# Altium schematic sheet MCU_SUPPORT_XS.SchDoc
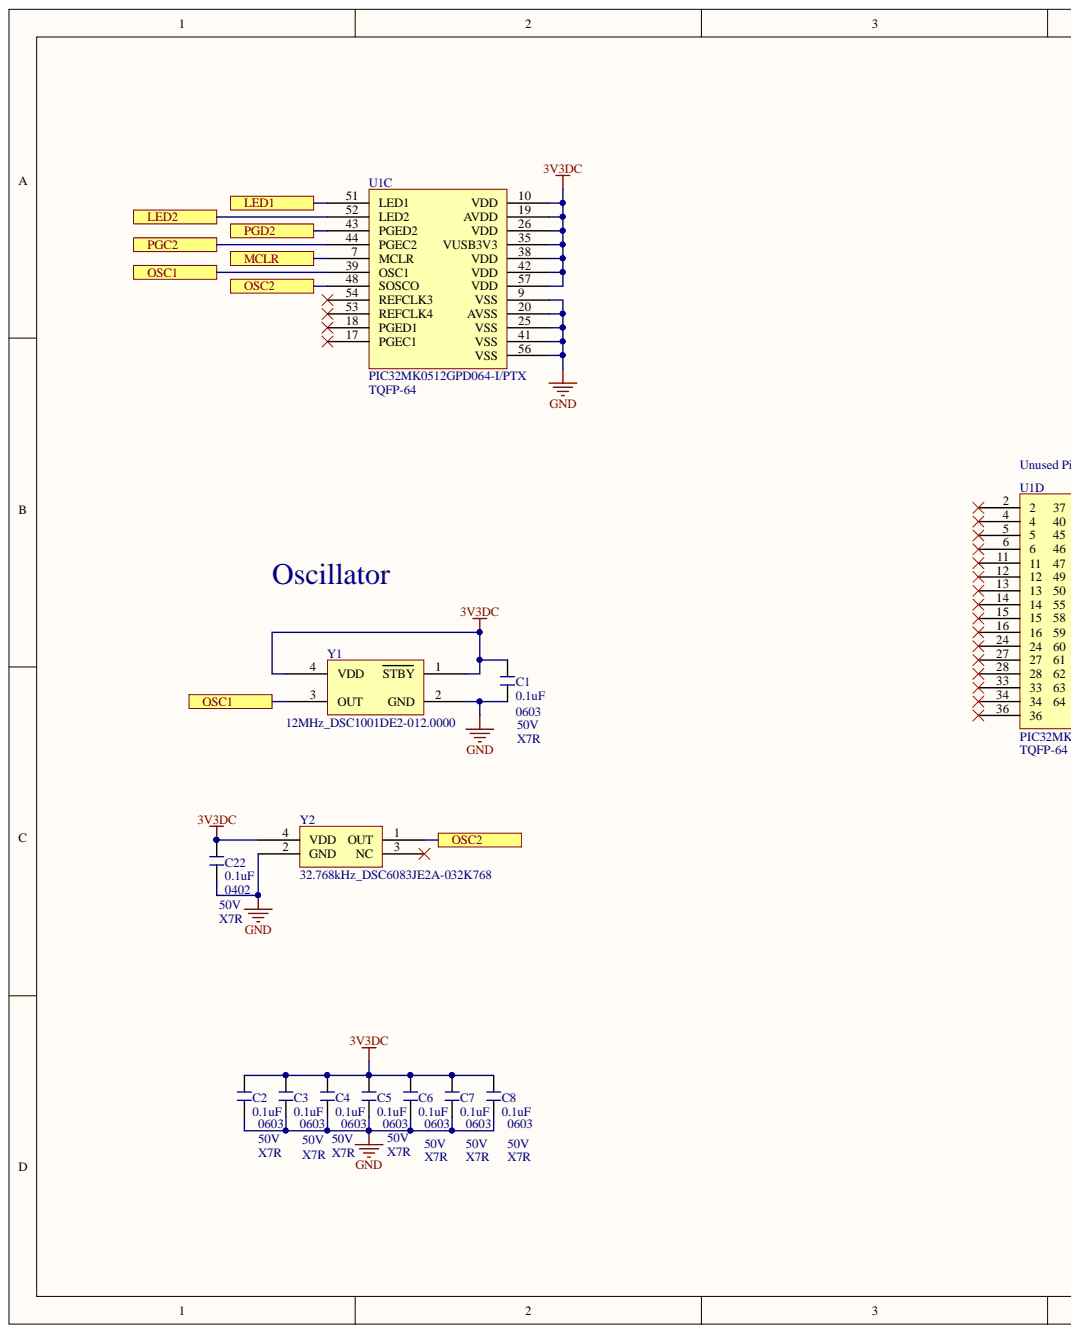
[diagram p0: MCU_SUPPORT_XS.SchDoc, left]
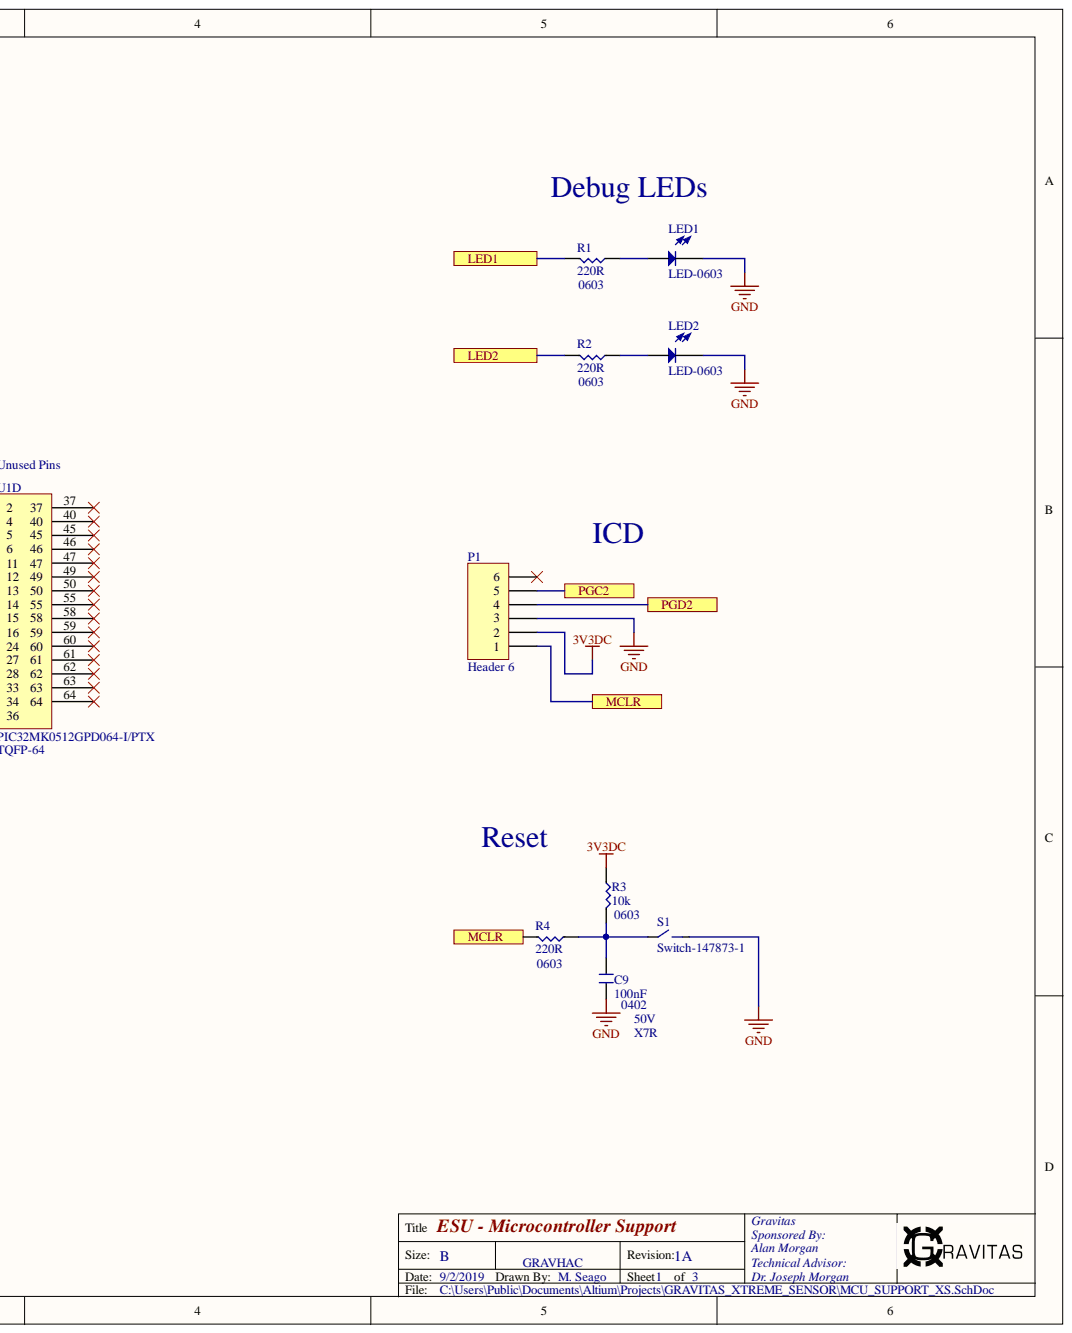
[diagram p1: MCU_SUPPORT_XS.SchDoc, right]

## Parts
C1  0.1uF  [NonPolar-0603]
C2  0.1uF  [NonPolar-0603]
C3  0.1uF  [NonPolar-0603]
C4  0.1uF  [NonPolar-0603]
C5  0.1uF  [NonPolar-0603]
C6  0.1uF  [NonPolar-0603]
C7  0.1uF  [NonPolar-0603]
C8  0.1uF  [NonPolar-0603]
C9  100nF  [NonPolar-0402]
C22  0.1uF  [NonPolar-0402]
LED1  LED-0603  [LED-0603]
LED2  LED-0603  [LED-0603]
P1  Header 6  [HDR1X6]
R1  220R  [NonPolar-0603]
R2  220R  [NonPolar-0603]
R3  10k  [NonPolar-0603]
R4  220R  [NonPolar-0603]
S1  Switch-147873-1  [Switch - 147873-1]
U1  PIC32MK0512GPD064-I/PTX  [V2_PIC32MK0512GPD064IPT]  (value not drawn)  (parts C,D)
Y1  12MHz_DSC1001DE2-012.0000  [12MHz_DSC1001DE2-012.0000]
Y2  32.768kHz_DSC6083JE2A-032K768  [32.768kHz_DSC6083JE2A-032K768]

## Ports
LED1, LED2, MCLR, OSC1, OSC2, PGC2, PGD2

## Nets
3V3DC: C1.2 C2.2 C3.2 C4.2 C5.2 C6.2 C7.2 C8.2 C22.2 P1.2 R3.2 U1.10(VDD) U1.19(AVDD) U1.26(VDD) U1.35(VUSB3V3) U1.38(VDD) U1.42(VDD) U1.57(VDD) Y1.1(STBY) Y1.4(VDD) Y2.4(VDD)
GND: C1.1 C2.1 C3.1 C4.1 C5.1 C6.1 C7.1 C8.1 C9.1 C22.1 LED1.2 LED2.2 P1.3 S1.2 U1.9(VSS) U1.20(AVSS) U1.25(VSS) U1.41(VSS) U1.56(VSS) Y1.2(GND) Y2.2(GND)
LED1: R1.1 U1.51(LED1) ; port LED1
LED2: R2.1 U1.52(LED2) ; port LED2
MCLR: P1.1 R4.1 U1.7(MCLR) ; port MCLR
OSC1: U1.39(OSC1) Y1.3(OUT) ; port OSC1
OSC2: U1.48(SOSCO) Y2.1(OUT) ; port OSC2
PGC2: P1.5 U1.44(PGEC2) ; port PGC2
PGD2: P1.4 U1.43(PGED2) ; port PGD2
NetC9_2: C9.2 R3.1 R4.2 S1.1
NetLED1_1: LED1.1 R1.2
NetLED2_1: LED2.1 R2.2

Not connected: P1 6; U1 2 4 5 6 11 12 13 14 15 16 17(PGEC1) 18(PGED1) 24 27 28 33 34 36 37 40 45 46 47 49 50 53(REFCLK4) 54(REFCLK3) 55 58 59 60 61 62 63 64; Y2 3(NC)

## Library data of the parts
C1-C8 = Cap - 0603: Component Kind: Standard; Library Name: GravLib.IntLib
C9 C22 = Cap - 0402: Component Kind: Standard; Library Name: GravLib.IntLib
P1 = Header 6: Header, 6-Pin; Component Kind: Standard; LatestRevisionDate: 17-Jul-2002; LatestRevisionNote: Re-released for DXP Platform.; Library Name: Miscellaneous Connectors.IntLib; Signal Integrity: Connector; Publisher: Altium Limited
R1 = Res - 0603: 0603; Component Kind: Standard; Parameter 1: 0603; Library Name: GravLib.IntLib
R2-R4 = Res - 0603: Component Kind: Standard; Library Name: GravLib.IntLib
LED1 LED2 = LED-0603: Component Kind: Standard; Library Name: GravLib.IntLib
S1 = Switch-147873-1: Component Kind: Standard; Library Name: GravLib.IntLib
U1 = PIC32MK0512GPD064-I/PTX: Component Kind: Standard; Library Name: GravLib.IntLib
Y1 = 12MHz_DSC1001DE2-012.0000: Component Kind: Standard; Library Name: GravLib.IntLib
Y2 = 32.768kHz_DSC6083JE2A-032K768: Component Kind: Standard; Library Name: GravLib.IntLib
